# Altium schematic sheet ExoIMU_Peripheral.SchDoc(ExoIMU_Peripheral)
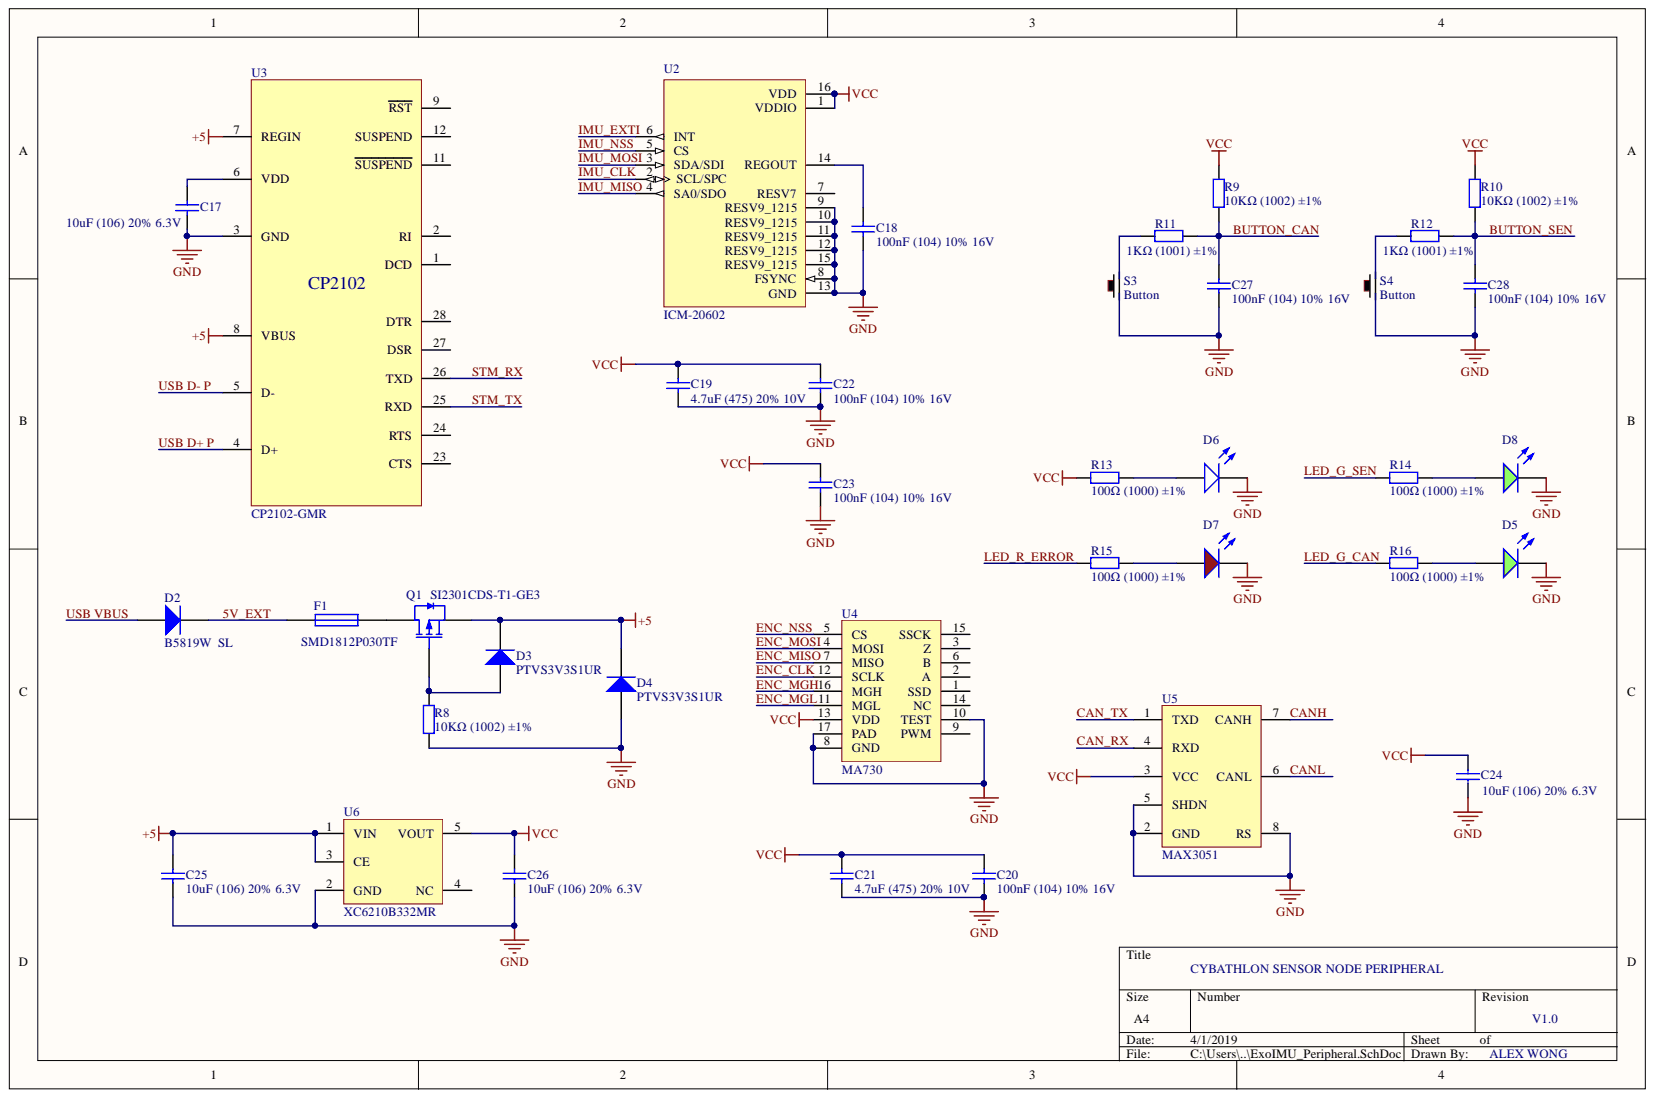
[diagram p0: ExoIMU_Peripheral.SchDoc]

## Parts
C17  10uF (106) 20% 6.3V  [0402_C]
C18  100nF (104) 10% 16V  [0402_C]
C19  4.7uF (475) 20% 10V  [0402_C]
C20  100nF (104) 10% 16V  [0402_C]
C21  4.7uF (475) 20% 10V  [0402_C]
C22  100nF (104) 10% 16V  [0402_C]
C23  100nF (104) 10% 16V  [0402_C]
C24  10uF (106) 20% 6.3V  [0402_C]
C25  10uF (106) 20% 6.3V  [0402_C]
C26  10uF (106) 20% 6.3V  [0402_C]
C27  100nF (104) 10% 16V  [0402_C]
C28  100nF (104) 10% 16V  [0402_C]
D2  B5819W  SL  [SOD-123]
D3  PTVS3V3S1UR  [SOD-123]
D4  PTVS3V3S1UR  [SOD-123]
D5  翠绿 0805  [0805_LED_S1]  (value not drawn)
D6  白灯 0805  [0805_LED_S1]  (value not drawn)
D7  红灯 0805  [0805_LED_S1]  (value not drawn)
D8  翠绿 0805  [0805_LED_S1]  (value not drawn)
F1  SMD1812P030TF  [1812_R]
Q1  SI2301CDS-T1-GE3  [SOT-23(SOT-23-3)]
R8  10KΩ (1002) ±1%  [0402_R]
R9  10KΩ (1002) ±1%  [0402_R]
R10  10KΩ (1002) ±1%  [0402_R]
R11  1KΩ (1001) ±1%  [0402_R]
R12  1KΩ (1001) ±1%  [0402_R]
R13  100Ω (1000) ±1%  [0402_R]
R14  100Ω (1000) ±1%  [0402_R]
R15  100Ω (1000) ±1%  [0402_R]
R16  100Ω (1000) ±1%  [0402_R]
S3  Button  [3.5x2.9 PushButton]
S4  Button  [3.5x2.9 PushButton]
U2  ICM-20602  [PQFN50P300X300X80-16N]
U3  CP2102-GMR  [QFN-28_5x5x05P]
U4  MA730  [QFN50P300X300X100_HS-17N]
U5  MAX3051  [SOT-23-8]
U6  XC6210B332MR  [SOT-23-5]

## Nets
+5: C25.2 D3.2 D4.2 Q1.2 U3.7(REGIN) U3.8(VBUS) U6.1(VIN) U6.3(CE)
GND: C17.1 C18.1 C19.1 C20.1 C21.1 C22.1 C23.1 C24.1 C25.1 C26.1 C27.1 C28.1 D4.1 D5.K D6.K D7.K D8.K R8.1 S3.1 S4.1 U2.8(FSYNC) U2.9(RESV9_1215) U2.10(RESV9_1215) U2.11(RESV9_1215) U2.12(RESV9_1215) U2.13(GND) U2.15(RESV9_1215) U3.3(GND) U4.8(GND) U4.10(TEST) U4.17(PAD) U5.2(GND) U5.5(SHDN) U5.8(RS) U6.2(GND)
VCC: C19.2 C20.2 C21.2 C22.2 C23.2 C24.2 C26.2 R9.2 R10.2 R13.1 U2.1(VDDIO) U2.16(VDD) U4.13(VDD) U5.3(VCC) U6.5(VOUT)
5V_EXT: D2.2 F1.1
BUTTON_CAN: C27.2 R9.1 R11.2
BUTTON_SEN: C28.2 R10.1 R12.2
CAN_RX: U5.4(RXD)
CAN_TX: U5.1(TXD)
CANH: U5.7(CANH)
CANL: U5.6(CANL)
ENC_CLK: U4.12(SCLK)
ENC_MGH: U4.16(MGH)
ENC_MGL: U4.11(MGL)
ENC_MISO: U4.7(MISO)
ENC_MOSI: U4.4(MOSI)
ENC_NSS: U4.5(CS)
IMU_CLK: U2.2(SCL/SPC)
IMU_EXTI: U2.6(INT)
IMU_MISO: U2.4(SA0/SDO)
IMU_MOSI: U2.3(SDA/SDI)
IMU_NSS: U2.5(CS)
LED_G_CAN: R16.1
LED_G_SEN: R14.1
LED_R_ERROR: R15.1
STM_RX: U3.26(TXD)
STM_TX: U3.25(RXD)
USB D+ P: U3.4(D+)
USB D- P: U3.5(D-)
USB VBUS: D2.1
NetC17_2: C17.2 U3.6(VDD)
NetC18_2: C18.2 U2.14(REGOUT)
NetD3_1: D3.1 Q1.1 R8.2
NetD5_A: D5.A R16.2
NetD6_A: D6.A R13.2
NetD7_A: D7.A R15.2
NetD8_A: D8.A R14.2
NetF1_2: F1.2 Q1.3
NetR11_1: R11.1 S3.2
NetR12_1: R12.1 S4.2

Not connected: U2 7(RESV7); U3 1(DCD) 2(RI) 9(RST) 11(SUSPEND) 12(SUSPEND) 23(CTS) 24(RTS) 27(DSR) 28(DTR); U4 1(SSD) 2(A) 3(Z) 6(B) 9(PWM) 14(NC) 15(SSCK); U6 4(NC)

## Library data of the parts
C17 C24-C26 = 0402 10uF (106) 20% 6.3V: 贴片电容; SuppliersPartNumber: C15525; Supplier: LC; Package: 0402; Library Name: Miscellaneous Devices LC.IntLib; ComponentLink1URL: http://www.szlcsc.com/product/details_16204.html; ComponentLink1Description: 供应商链接; Component Kind: Standard
C18 C20 C22 C23 C27 C28 = 0402 100nF (104) 10% 16V: 贴片电容; SuppliersPartNumber: C1525; Supplier: LC; Package: 0402; Library Name: Cap.SchLib; ComponentLink1URL: http://www.szlcsc.com/product/details_1877.html; ComponentLink1Description: 供应商链接; Component Kind: Standard
C19 C21 = 0402 4.7uF (475) 20% 10V: 贴片电容; SuppliersPartNumber: C23733; Supplier: LC; Package: 0402; Library Name: Cap.SchLib; ComponentLink1URL: http://www.szlcsc.com/product/details_24469.html; ComponentLink1Description: 供应商链接; Component Kind: Standard
D2-D4 = SOD-123 B5819W  SL: 肖特基二极管; SuppliersPartNumber: C8598; Supplier: LC; Package: SOD-123; Library Name: Diode.SchLib; ComponentLink1URL: http://www.szlcsc.com/product/details_9093.html; ComponentLink1Description: 供应商链接; Component Kind: Standard
D5 D8 = 0805 翠绿: 发光二极管; SuppliersPartNumber: C2297; Supplier: LC; Package: 0805; Library Name: LED.SchLib; ComponentLink1URL: http://www.szlcsc.com/product/details_2670.html; ComponentLink1Description: 供应商链接; Component Kind: Standard
D6 = 0805 白灯: 发光二极管; SuppliersPartNumber: C34499; Supplier: LC; Package: 0805; Library Name: LED.SchLib; ComponentLink1URL: http://www.szlcsc.com/product/details_35468.html; ComponentLink1Description: 供应商链接; Component Kind: Standard
D7 = 0805 红灯: 发光二极管; SuppliersPartNumber: C2295; Supplier: LC; Package: 0805; Library Name: LED.SchLib; ComponentLink1URL: http://www.szlcsc.com/product/details_2668.html; ComponentLink1Description: 供应商链接; Component Kind: Standard
F1 = Fuse 1: Fuse; Simulation: FUSE; Published: 8-Jun-2000; PackageReference: PIN-W2/E2.8; PackageDescription: Thru-Hole; 2 Leads; Library Name: Miscellaneous Devices.IntLib; LatestRevisionNote: Re-released for DXP Platform.; LatestRevisionDate: 17-Jul-2002; Component Kind: Standard; Publisher: Altium Limited
Q1 = SI2301CDS-T1-GE3: MOS(场效应管); SuppliersPartNumber: C10487; Supplier: LC; Package: SOT-23(SOT-23-3); Library Name: MOS.SchLib; ComponentLink1URL: http://www.szlcsc.com/product/details_11030.html; ComponentLink1Description: 供应商链接; Component Kind: Standard
R8-R10 = 0402 10KΩ (1002) 1%: 贴片电阻; SuppliersPartNumber: C25744; Supplier: LC; Package: 0402; Library Name: RES.SchLib; ComponentLink1URL: http://www.szlcsc.com/product/details_26487.html; ComponentLink1Description: 供应商链接; Component Kind: Standard
R11 R12 = 0402 1KΩ (1001) 1%: 贴片电阻; SuppliersPartNumber: C11702; Supplier: LC; Package: 0402; Library Name: RES.SchLib; ComponentLink1URL: http://www.szlcsc.com/product/details_12256.html; ComponentLink1Description: 供应商链接; Component Kind: Standard
R13-R16 = 0402 100Ω (1000) 1%: 贴片电阻; SuppliersPartNumber: C25076; Supplier: LC; Package: 0402; Library Name: RES.SchLib; ComponentLink1URL: http://www.szlcsc.com/product/details_25819.html; ComponentLink1Description: 供应商链接; Component Kind: Standard
U2 = ICM-20602: World's Best 6-Axis Integrated s; MF: TDK InvenSense; SnapEDA_Link: https://www.snapeda.com/parts/ICM-20602/TDK%20InvenSense/view-part/?ref=snap; Package: PQFN-16 TDK-InvenSense; MP: ICM-20602; Digi-Key_Purchase_URL: https://www.digikey.hk/product-detail/en/tdk-invensense/ICM-20602/1428-1060-1-ND/5872875?utm_source=snapeda&utm_medium=aggregator&utm_campaign=symbol; Digi-Key_Part_Number: 1428-1060-1-ND; Component Kind: Standard; Library Name: alexLib.SchLib
U3 = CP2102-GMR_[C6568]: USB; SuppliersPartNumber: C6568; Supplier: LC; Package: QFN-28_5x5x05P; Library Name: IC.SchLib; ComponentLink1URL: http://www.szlcsc.com/product/details_7033.html; ComponentLink1Description: 供应商链接; Component Kind: Standard
S3 S4 = Button: Component Kind: Standard; Library Name: alexLib.SchLib
U4 = MA730: Component Kind: Standard; Library Name: alexLib.SchLib
U5 = MAX3051: Component Kind: Standard; Library Name: alexLib.SchLib
U6 = XC6210B332MR: Component Kind: Standard; Library Name: alexLib.SchLib
